# Altium schematic sheet HBridge&Rectifier.SchDoc
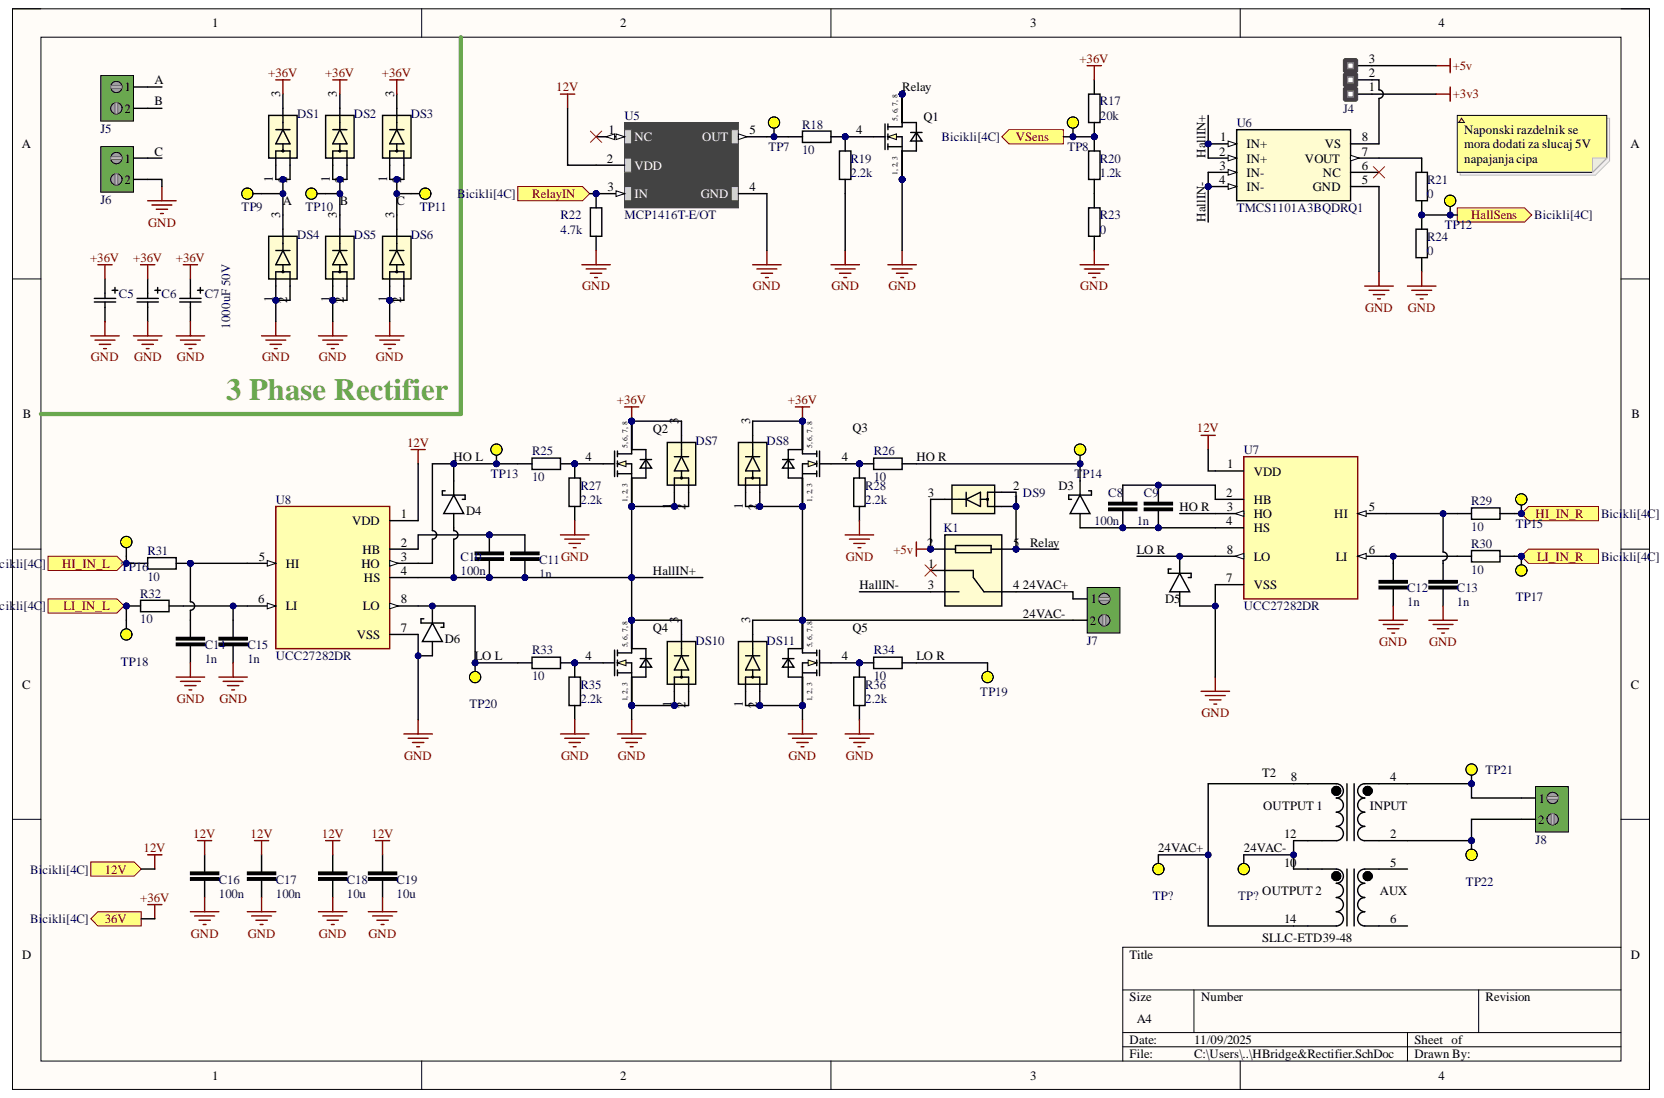
[diagram p0: HBridge&Rectifier.SchDoc]

## Parts
C5  1000uF 50V  [CAP_12.5 X 25_NCH]
C6  1000uF 50V  [CAP_12.5 X 25_NCH]
C7  1000uF 50V  [CAP_12.5 X 25_NCH]
C8  100n  [CAP_0805]
C9  1n  [CAP_0805]
C10  100n  [CAP_0805]
C11  1n  [CAP_0805]
C12  1n  [CAP_0805]
C13  1n  [CAP_0805]
C14  1n  [CAP_0805]
C15  1n  [CAP_0805]
C16  100n  [CAP_0805]
C17  100n  [CAP_0805]
C18  10u  [CAP_1206]
C19  10u  [CAP_1206]
D3  SCH_DIODE  [SMA]  (value not drawn)
D4  SCH_DIODE  [SMA]  (value not drawn)
D5  SCH_DIODE  [SMA]  (value not drawn)
D6  SCH_DIODE  [SMA]  (value not drawn)
DS1  PMEG45U10EPDZ  [PMEG45U10EPDZ_NEX]  (value not drawn)
DS2  PMEG45U10EPDZ  [PMEG45U10EPDZ_NEX]  (value not drawn)
DS3  PMEG45U10EPDZ  [PMEG45U10EPDZ_NEX]  (value not drawn)
DS4  PMEG45U10EPDZ  [PMEG45U10EPDZ_NEX]  (value not drawn)
DS5  PMEG45U10EPDZ  [PMEG45U10EPDZ_NEX]  (value not drawn)
DS6  PMEG45U10EPDZ  [PMEG45U10EPDZ_NEX]  (value not drawn)
DS7  PMEG45U10EPDZ  [PMEG45U10EPDZ_NEX]  (value not drawn)
DS8  PMEG45U10EPDZ  [PMEG45U10EPDZ_NEX]  (value not drawn)
DS9  PMEG45U10EPDZ  [PMEG45U10EPDZ_NEX]  (value not drawn)
DS10  PMEG45U10EPDZ  [PMEG45U10EPDZ_NEX]  (value not drawn)
DS11  PMEG45U10EPDZ  [PMEG45U10EPDZ_NEX]  (value not drawn)
J4  3Pin  [HEADER3PIN]  (value not drawn)
J5  Wire Terminal Block 2 pin 5mm  [WireTerminalPCB5mm2PIN]  (value not drawn)
J6  Wire Terminal Block 2 pin 5mm  [WireTerminalPCB5mm2PIN]  (value not drawn)
J7  Wire Terminal Block 2 pin 5mm  [WireTerminalPCB5mm2PIN]  (value not drawn)
J8  Wire Terminal Block 2 pin 5mm  [WireTerminalPCB5mm2PIN]  (value not drawn)
K1  J107F1CS125VDC.36  [RELAY5_J107F-1C_CRS]  (value not drawn)
Q1  IQE022N06LM5  [IFX-PG-TSON-8-5-V]  (value not drawn)
Q2  IQE022N06LM5  [IFX-PG-TSON-8-5-V]  (value not drawn)
Q3  IQE022N06LM5  [IFX-PG-TSON-8-5-V]  (value not drawn)
Q4  IQE022N06LM5  [IFX-PG-TSON-8-5-V]  (value not drawn)
Q5  IQE022N06LM5  [IFX-PG-TSON-8-5-V]  (value not drawn)
R17  20k  [RESISTOR_0805]
R18  10  [RESISTOR_1206]
R19  2.2k  [RESISTOR_1206]
R20  1.2k  [RESISTOR_0805]
R21  0  [RESISTOR_0805]
R22  4.7k  [RESISTOR_0805]
R23  0  [RESISTOR_0805]
R24  0  [RESISTOR_0805]
R25  10  [RESISTOR_1206]
R26  10  [RESISTOR_1206]
R27  2.2k  [RESISTOR_1206]
R28  2.2k  [RESISTOR_1206]
R29  10  [RESISTOR_1206]
R30  10  [RESISTOR_1206]
R31  10  [RESISTOR_1206]
R32  10  [RESISTOR_1206]
R33  10  [RESISTOR_1206]
R34  10  [RESISTOR_1206]
R35  2.2k  [RESISTOR_1206]
R36  2.2k  [RESISTOR_1206]
T2  SLLC-ETD39-48  [XFMR_SLLC-ETD39-48]
TP7  TP  [TP]
TP8  TP  [TP]
TP9  TP  [TP]
TP10  TP  [TP]
TP11  TP  [TP]
TP12  TP  [TP]
TP13  TP  [TP]
TP14  TP  [TP]
TP15  TP  [TP]
TP16  TP  [TP]
TP17  TP  [TP]
TP18  TP  [TP]
TP19  TP  [TP]
TP20  TP  [TP]
TP21  TP  [TP]
TP22  TP  [TP]
TP?  TP  [TP]
TP?  TP  [TP]
U5  MCP1416T-E/OT  [SOT95P270X145-5N]
U6  TMCS1101A3BQDRQ1  [SOIC8_D_TEX]
U7  UCC27282DR  [SOIC127P599X175-8N]
U8  UCC27282DR  [SOIC127P599X175-8N]

## Ports
12V, 36V, HallSens, HI_IN_L, HI_IN_R, LI_IN_L, LI_IN_R, RelayIN, VSens

## Nets
+3v3: J4.1
+5v: DS9.3 J4.3 K1.2
GND: C5.2 C6.2 C7.2 C12.1 C13.1 C14.1 C15.1 C16.1 C17.1 C18.1 C19.1 D5.1 D6.1 DS4.1 DS4.2 DS5.1 DS5.2 DS6.1 DS6.2 DS10.1 DS10.2 DS11.1 DS11.2 J6.2 Q1.1 Q1.2 Q1.3 Q4.1 Q4.2 Q4.3 Q5.1 Q5.2 Q5.3 R19.1 R22.1 R23.1 R24.1 R27.1 R28.1 R35.1 R36.1 U5.4(GND) U6.5(GND) U7.7(VSS) U8.7(VSS)
12V: C16.2 C17.2 C18.2 C19.2 U5.2(VDD) U7.1(VDD) U8.1(VDD) ; port 12V
24VAC+: J7.1 K1.4 T2.8 T2.14 TP?.1
24VAC-: DS8.1 DS8.2 DS11.3 J7.2 Q3.1 Q3.2 Q3.3 Q5.5 Q5.6 Q5.7 Q5.8 T2.10 T2.12 TP?.1
+36V: C5.1 C6.1 C7.1 DS1.3 DS2.3 DS3.3 DS7.3 DS8.3 Q2.5 Q2.6 Q2.7 Q2.8 Q3.5 Q3.6 Q3.7 Q3.8 R17.2 ; port 36V
A: DS1.1 DS1.2 DS4.3 J5.1 TP9.1
B: DS2.1 DS2.2 DS5.3 J5.2 TP10.1
C: DS3.1 DS3.2 DS6.3 J6.1 TP11.1
HallIN+: C10.1 C11.1 D4.1 DS7.1 DS7.2 DS10.3 Q2.1 Q2.2 Q2.3 Q4.5 Q4.6 Q4.7 Q4.8 U6.1(IN+) U6.2(IN+) U8.4(HS)
HallIN-: K1.3 U6.3(IN-) U6.4(IN-)
HallSens: R21.1 R24.2 TP12.1 ; port HallSens
HI_IN_L: R31.1 TP16.1 ; port HI_IN_L
HI_IN_R: R29.2 TP15.1 ; port HI_IN_R
HO L: D4.2 R25.1 TP13.1 U8.3(HO)
HO R: D3.2 R26.2 TP14.1 U7.3(HO)
LI_IN_L: R32.1 TP18.1 ; port LI_IN_L
LI_IN_R: R30.2 TP17.1 ; port LI_IN_R
LO L: D6.2 R33.1 TP20.1 U8.8(LO)
LO R: D5.2 R34.2 TP19.1 U7.8(LO)
Relay: DS9.1 DS9.2 K1.5 Q1.5 Q1.6 Q1.7 Q1.8
RelayIN: R22.2 U5.3(IN) ; port RelayIN
VSens: R17.1 R20.2 TP8.1 ; port VSens
NetC8_1: C8.1 C9.1 D3.1 U7.4(HS)
NetC8_2: C8.2 C9.2 U7.2(HB)
NetC10_2: C10.2 C11.2 U8.2(HB)
NetC12_2: C12.2 R30.1 U7.6(LI)
NetC13_2: C13.2 R29.1 U7.5(HI)
NetC14_2: C14.2 R31.2 U8.5(HI)
NetC15_2: C15.2 R32.2 U8.6(LI)
NetJ4_2: J4.2 U6.8(VS)
NetJ8_1: J8.1 T2.4 TP21.1
NetJ8_2: J8.2 T2.2 TP22.1
NetQ1_4: Q1.4 R18.2 R19.2
NetQ2_4: Q2.4 R25.2 R27.2
NetQ3_4: Q3.4 R26.1 R28.2
NetQ4_4: Q4.4 R33.2 R35.2
NetQ5_4: Q5.4 R34.1 R36.2
NetR18_1: R18.1 TP7.1 U5.5(OUT)
NetR20_1: R20.1 R23.2
NetR21_2: R21.2 U6.7(VOUT)

Not connected: K1 1; T2 5 6; U5 1(NC); U6 6(NC)

## Library data of the parts
C5-C7 = UVY1H102MHD: Manufacturer_Name: Nichicon; Component Kind: Standard; Description: UVY1H102MHD; Library Name: UVY1H102MHD.SchLib; Manufacturer_Part_Number: UVY1H102MHD; Copyright: Copyright (C) 2025 Ultra Librarian. All rights reserved.
C8 C10 C16 C17 = C00079: CAP 100nF ±10% 50V 0805 X7R; capacitance: 100n; Component Kind: Standard; Library Name: Kondezatori_Integrisano.IntLib
C9 C11-C15 = C00059: CAP 1nF ±10% 50V 0805 X7R; capacitance: 1n; Component Kind: Standard; Library Name: Kondezatori_Integrisano.IntLib
C18 C19 = C00085: CAP 10uF ±10% 10V 0805 X5R; capacitance: 10u; Component Kind: Standard; Library Name: Kondezatori_Integrisano.IntLib
DS1-DS11 = PMEG45U10EPDZ: No Description Available; Manufacturer_Name: Nexperia; Component Kind: Standard; Library Name: PMEG45U10EPDZ.SchLib; Manufacturer_Part_Number: PMEG45U10EPDZ; RefDes: RefDes; Type: Type; Copyright: Copyright (C) 2025 Ultra Librarian. All rights reserved.
J4 = HEADER3PIN: 3 PIN Male Header 2.54mm pitch; Component Kind: Standard; Library Name: Connectors.SchLib
J5-J8 = Wire Terminal Block 2 pin 5mm: Wire Terminal PCB Block 2 pin 5mm; Component Kind: Standard; Library Name: Connectors.SchLib
K1 = J107F1CS125VDC.36: No Description Available; Manufacturer_Name: CIT Relay & Switch; Component Kind: Standard; Library Name: J107F1CS125VDCP36.SchLib; Manufacturer_Part_Number: J107F1CS125VDC.36; RefDes: RefDes; Type: Power Relays; Copyright: Copyright (C) 2025 Ultra Librarian. All rights reserved.
Q1-Q5 = IQE022N06LM5: Insulated-Gate Field-Effect Transistor (IGFET), N-Channel, Enhancement, Body Diode, Pin 1 Source, 2 Source, 3 Source, 4 Gate, 5 Drain, 6 Drain, 7 Drain, 8 Drain, 8 Pins; Manufacturer: Infineon Technologies; Component Kind: Standard; Library Name: IQE022N06LM5.SchLib; Manufacturer Order Number: IQE022N06LM5; PackageReference: PG-TSON-8-5
R17 = R000054: RES  20k OHM 1% 1/8W 0805; Component Kind: Standard; resistance: 20k; Library Name: Otpornici_Integrisano.IntLib
R18 R25 R26 R29-R34 = R000002: RES  10 OHM 1% 1/8W 0805; Component Kind: Standard; resistance: 10; Library Name: Otpornici_Integrisano.IntLib
R19 R27 R28 R35 R36 = R000060: RES  2k2 OHM 1% 1/8W 0805; Component Kind: Standard; resistance: 2.2k; Library Name: Otpornici_Integrisano.IntLib
R20 = R000018: RES  1k2 OHM 1% 1/8W 0805; Component Kind: Standard; resistance: 1.2k; Library Name: Otpornici_Integrisano.IntLib
R21 R23 R24 = R000000: RES  0 OHM JUMPER 1/8W 0805; resistance: 1; Component Kind: Standard; Supplier 1: Mouser; Supplier 2: Mouser; Supplier 3: Mouser; Supplier Part Number 1: 71-CRCW0805-1-E3; Supplier Part Number 2: 667-ERJ-6RQF1R0V; Supplier Part Number 3: 667-ERJ-6BQF1R0V; Library Name: Otpornici_Integrisano.IntLib
R22 = R000116: RES  4k7 OHM 1% 1/8W 0805; Component Kind: Standard; resistance: 4.7k; Library Name: Otpornici_Integrisano.IntLib
T2 = SLLC-ETD39-48: High Frequency Resonant Transformers; MF: Signal Transformer; Check_prices: https://www.snapeda.com/parts/SLLC-ETD39-48/Signal+Transformer/view-part/?ref=eda; Component Kind: Standard; Library Name: SLLC-ETD39-48.SchLib; MP: SLLC-ETD39-48; Package: None; SnapEDA_Link: https://www.snapeda.com/parts/SLLC-ETD39-48/Signal+Transformer/view-part/?ref=snap
TP7-TP22 TP? TP? = TP: Test Point 1.5mm radius; Component Kind: Standard; Library Name: Otpornici.SchLib
U5 = MCP1416T-E/OT: Low-Side Gate Driver IC Non-Inverting SOT-23-5; MF: Microchip; Availability: Good; Check_prices: https://www.snapeda.com/parts/MCP1416T-E/OT/Microchip/view-part/287469/?ref=eda; Component Kind: Standard; Library Name: MCP1416T-E_OT.SchLib; MP: MCP1416T-E/OT; Package: SOT-23-5 Microchip; Price: None; Purchase-URL: https://pricing.snapeda.com/search/part/MCP1416T-E/OT/?ref=eda; SnapEDA_Link: https://www.snapeda.com/parts/MCP1416T-E/OT/Microchip/view-part/287469/?ref=snap
U6 = TMCS1101A3BQDRQ1: AEC-Q100, Â±600-V,Â precision, isolated current sensor with internal reference 8-SOIC -40 to 125; Manufacturer_Name: Texas Instruments; Component Kind: Standard; Datasheet: https://www.ti.com/lit/gpn/tmcs1101-q1; Library Name: TMCS1101A3BQDRQ1.SchLib; Manufacturer_Part_Number: TMCS1101A3BQDRQ1; RefDes: RefDes; Type: Type; Copyright: Copyright (C) 2025 Ultra Librarian. All rights reserved.
U7 U8 = UCC27282DR: 3-A, 120-V half-bridge gate driver with 5-V UVLO, interlock and enable; MF: Texas Instruments; Check_prices: https://www.snapeda.com/parts/UCC27282DR/Texas+Instruments/view-part/?ref=eda; Component Kind: Standard; Library Name: UCC27282DR.SchLib; MP: UCC27282DR; Package: None; SnapEDA_Link: https://www.snapeda.com/parts/UCC27282DR/Texas+Instruments/view-part/?ref=snap
D3-D6 = SCH_DIODE: Component Kind: Standard; Library Name: Schlib.SchLib
